# Altium schematic sheet VoltageRegulator12V.schdoc
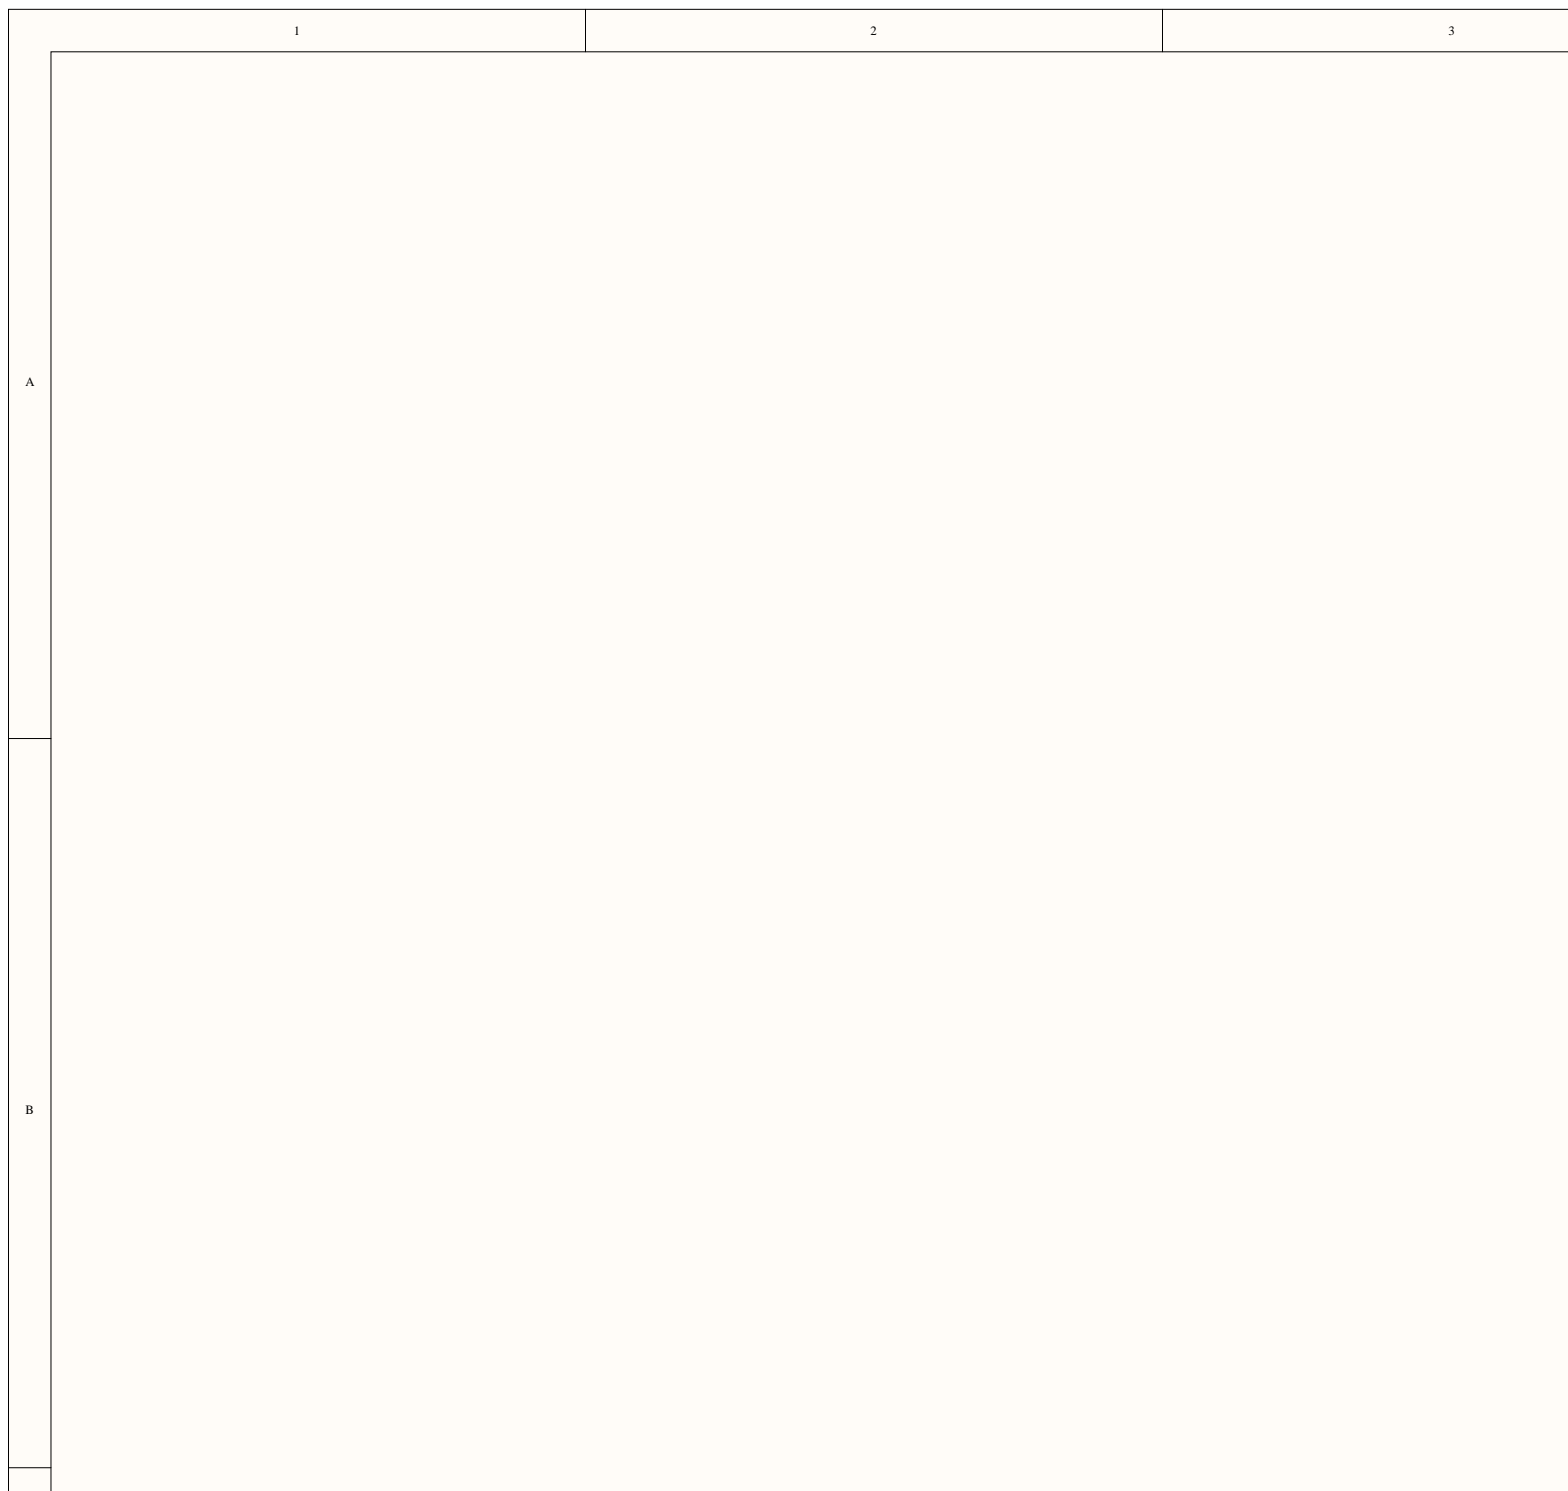
[diagram p0: VoltageRegulator12V.schdoc, top left]
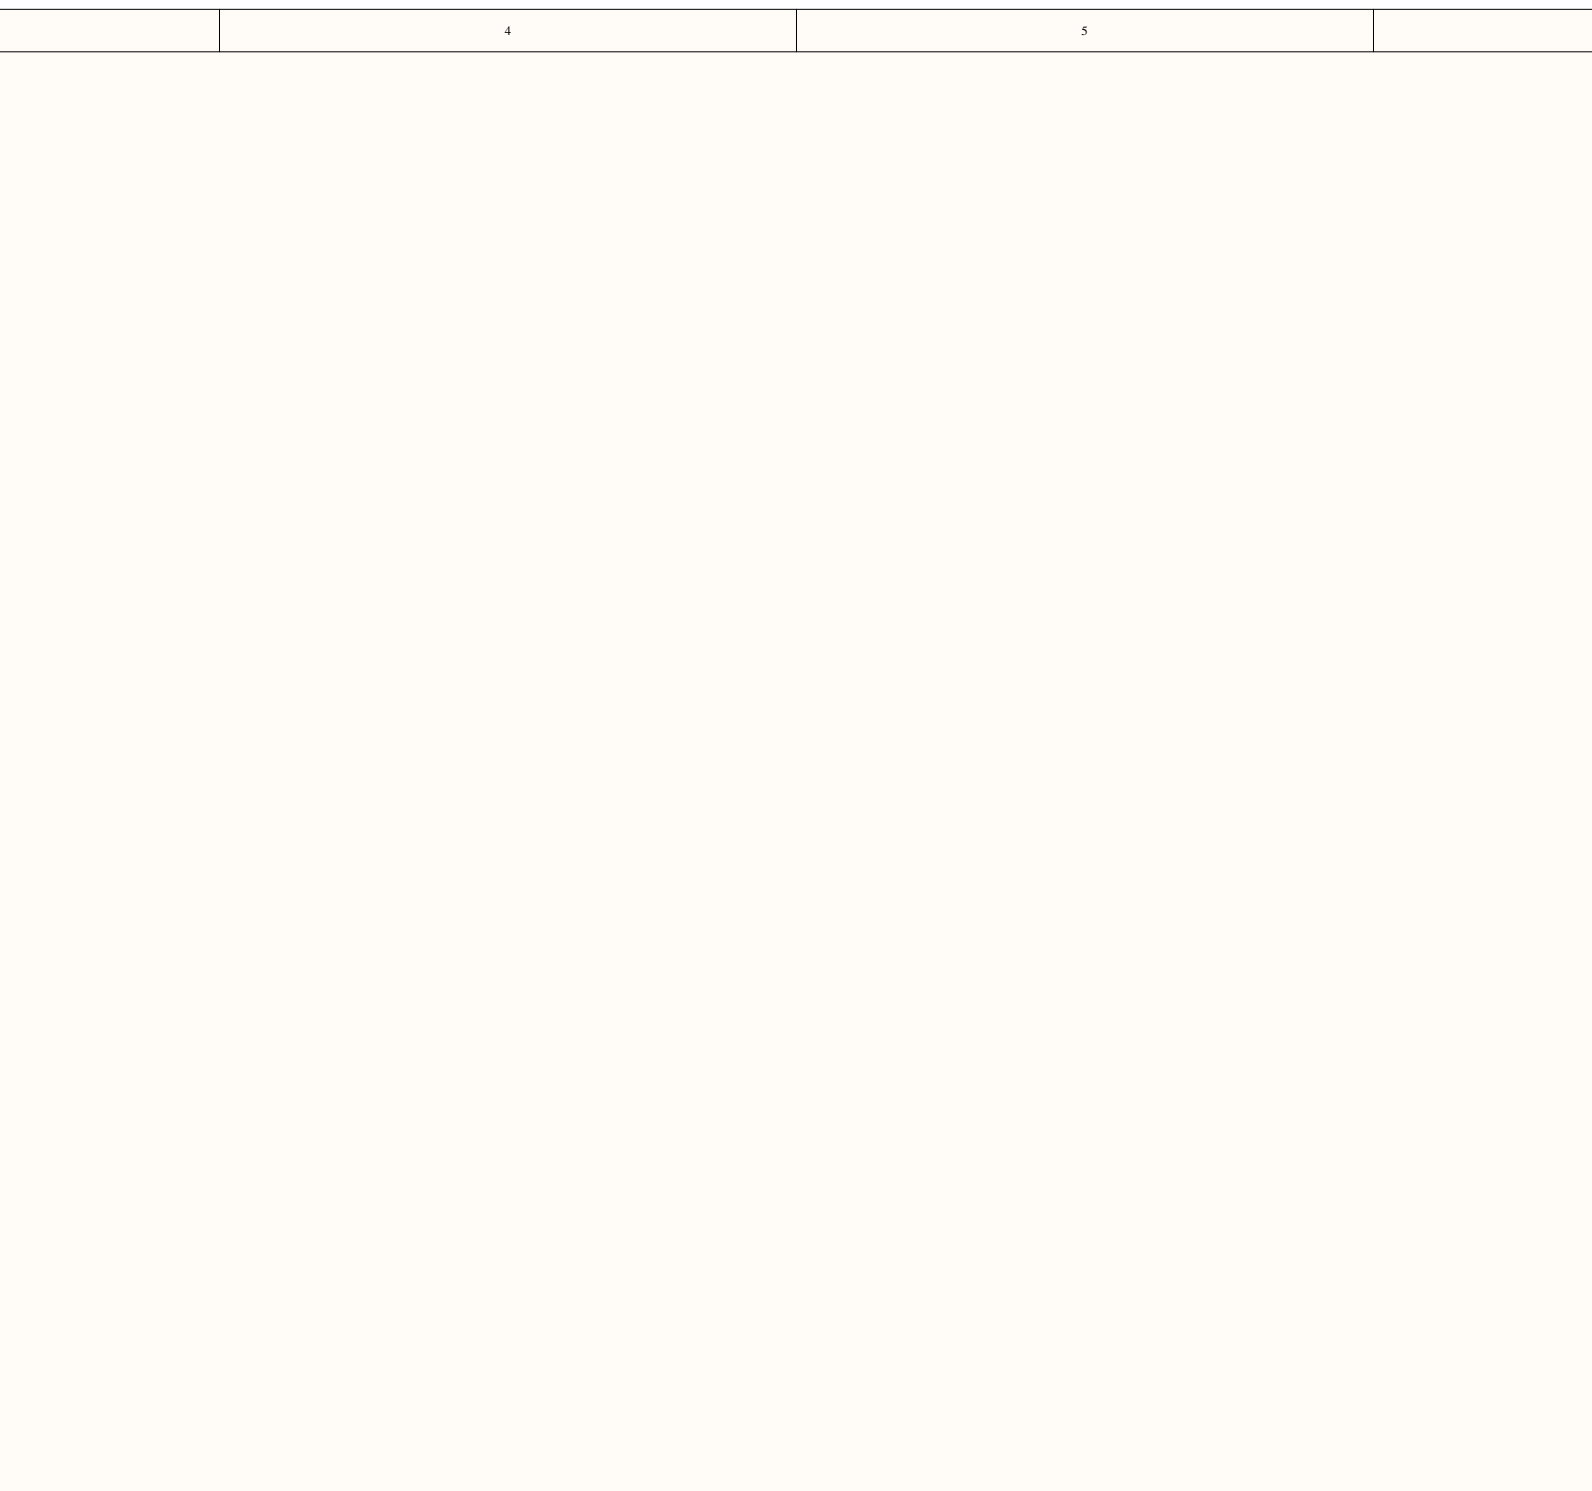
[diagram p1: VoltageRegulator12V.schdoc, top centre]
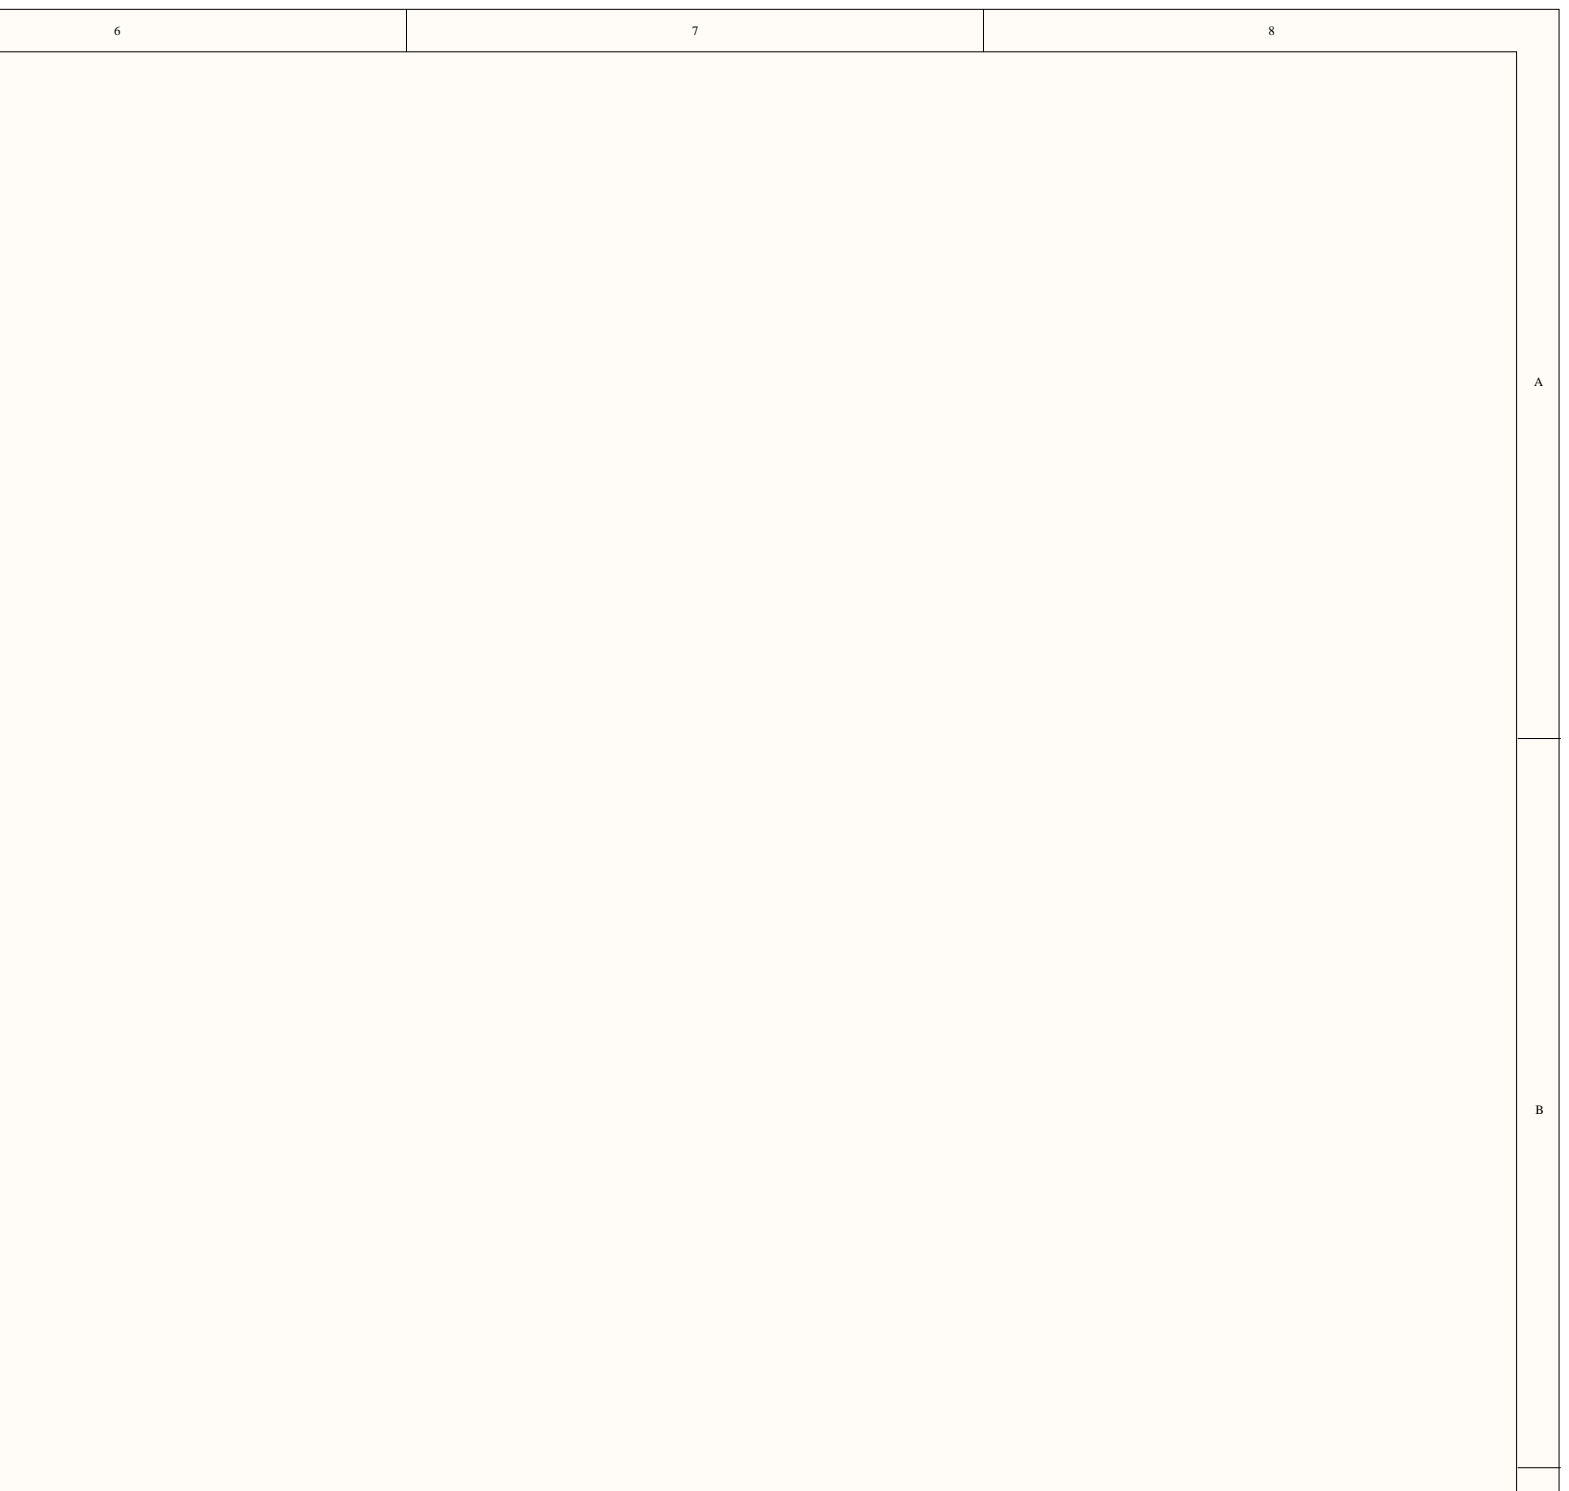
[diagram p2: VoltageRegulator12V.schdoc, top right]
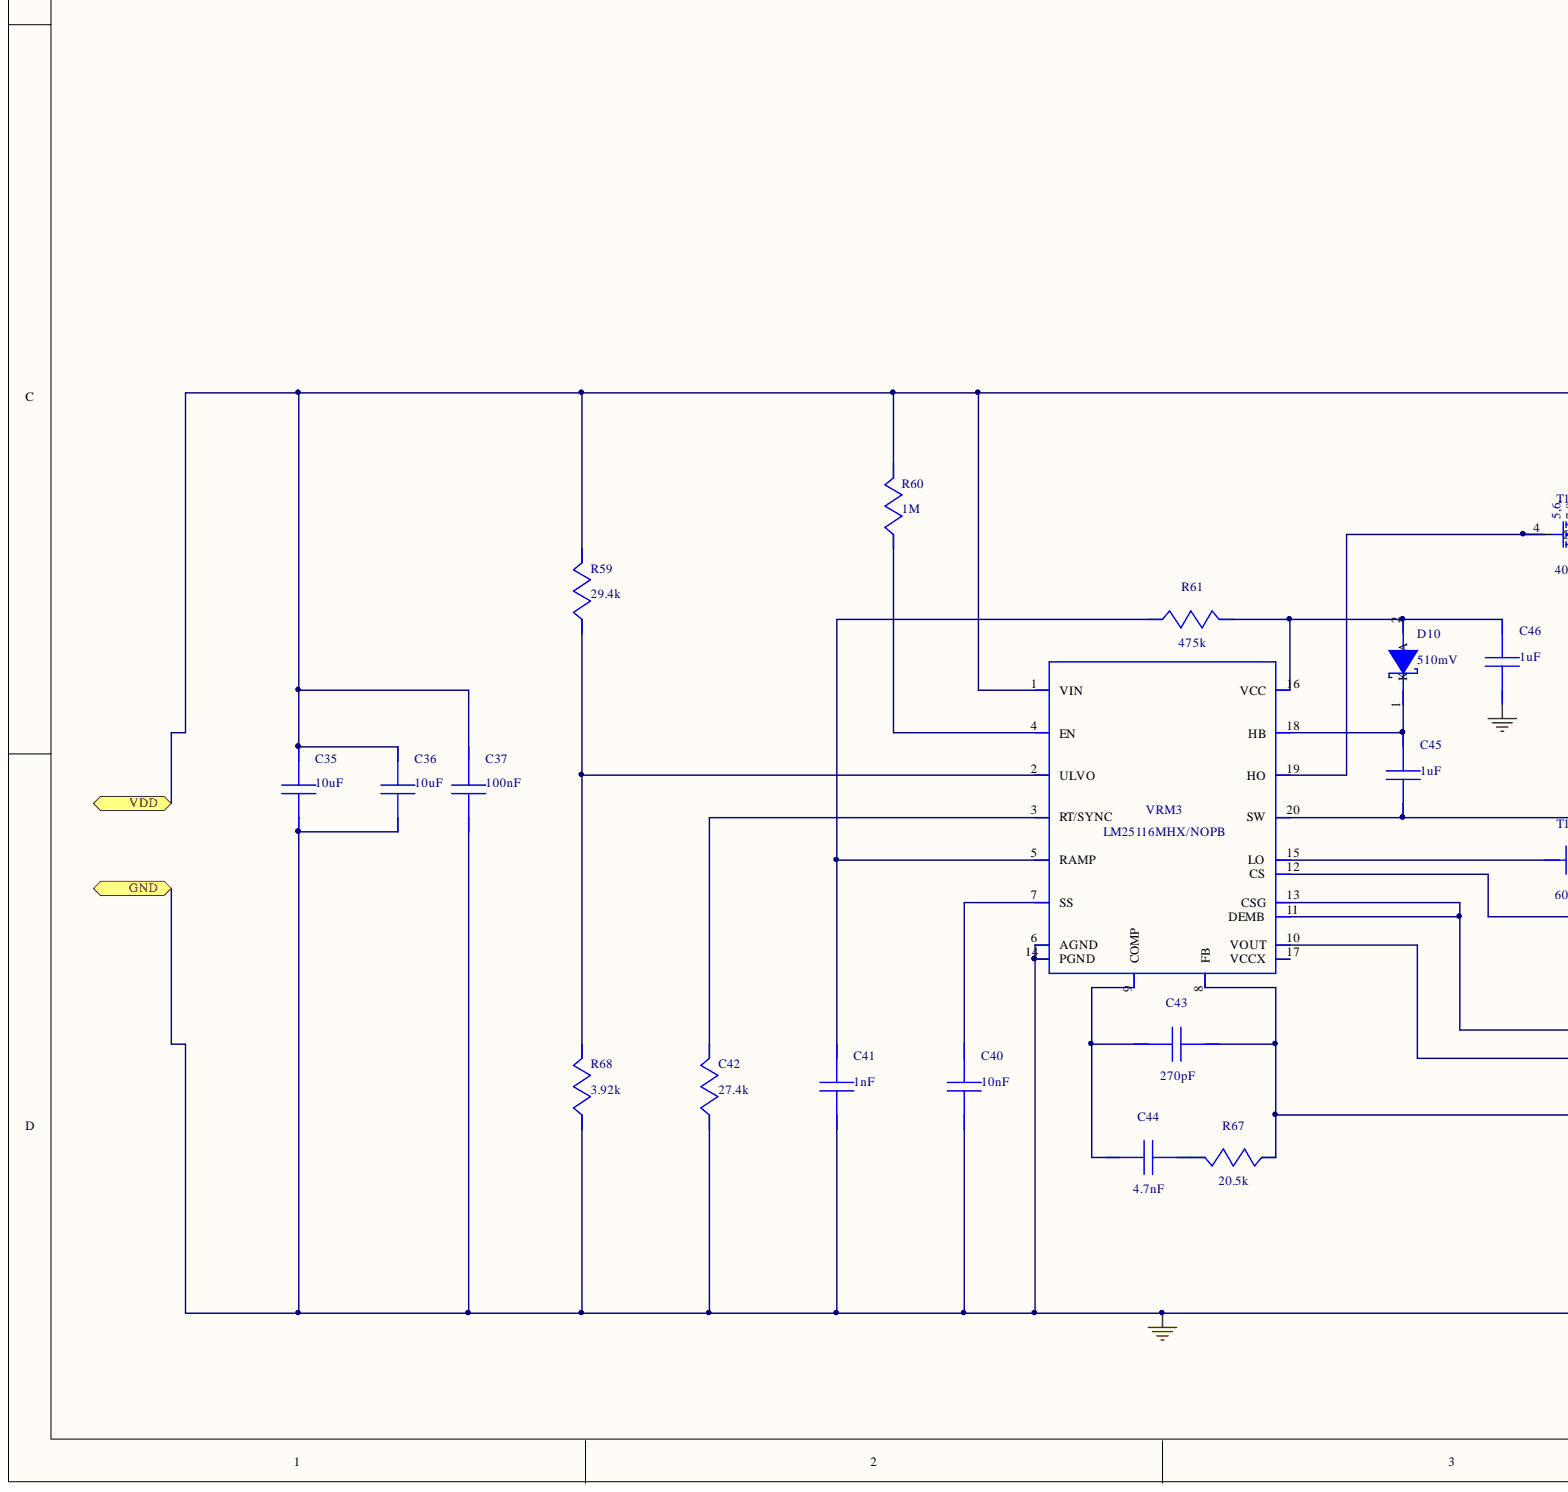
[diagram p3: VoltageRegulator12V.schdoc, bottom left]
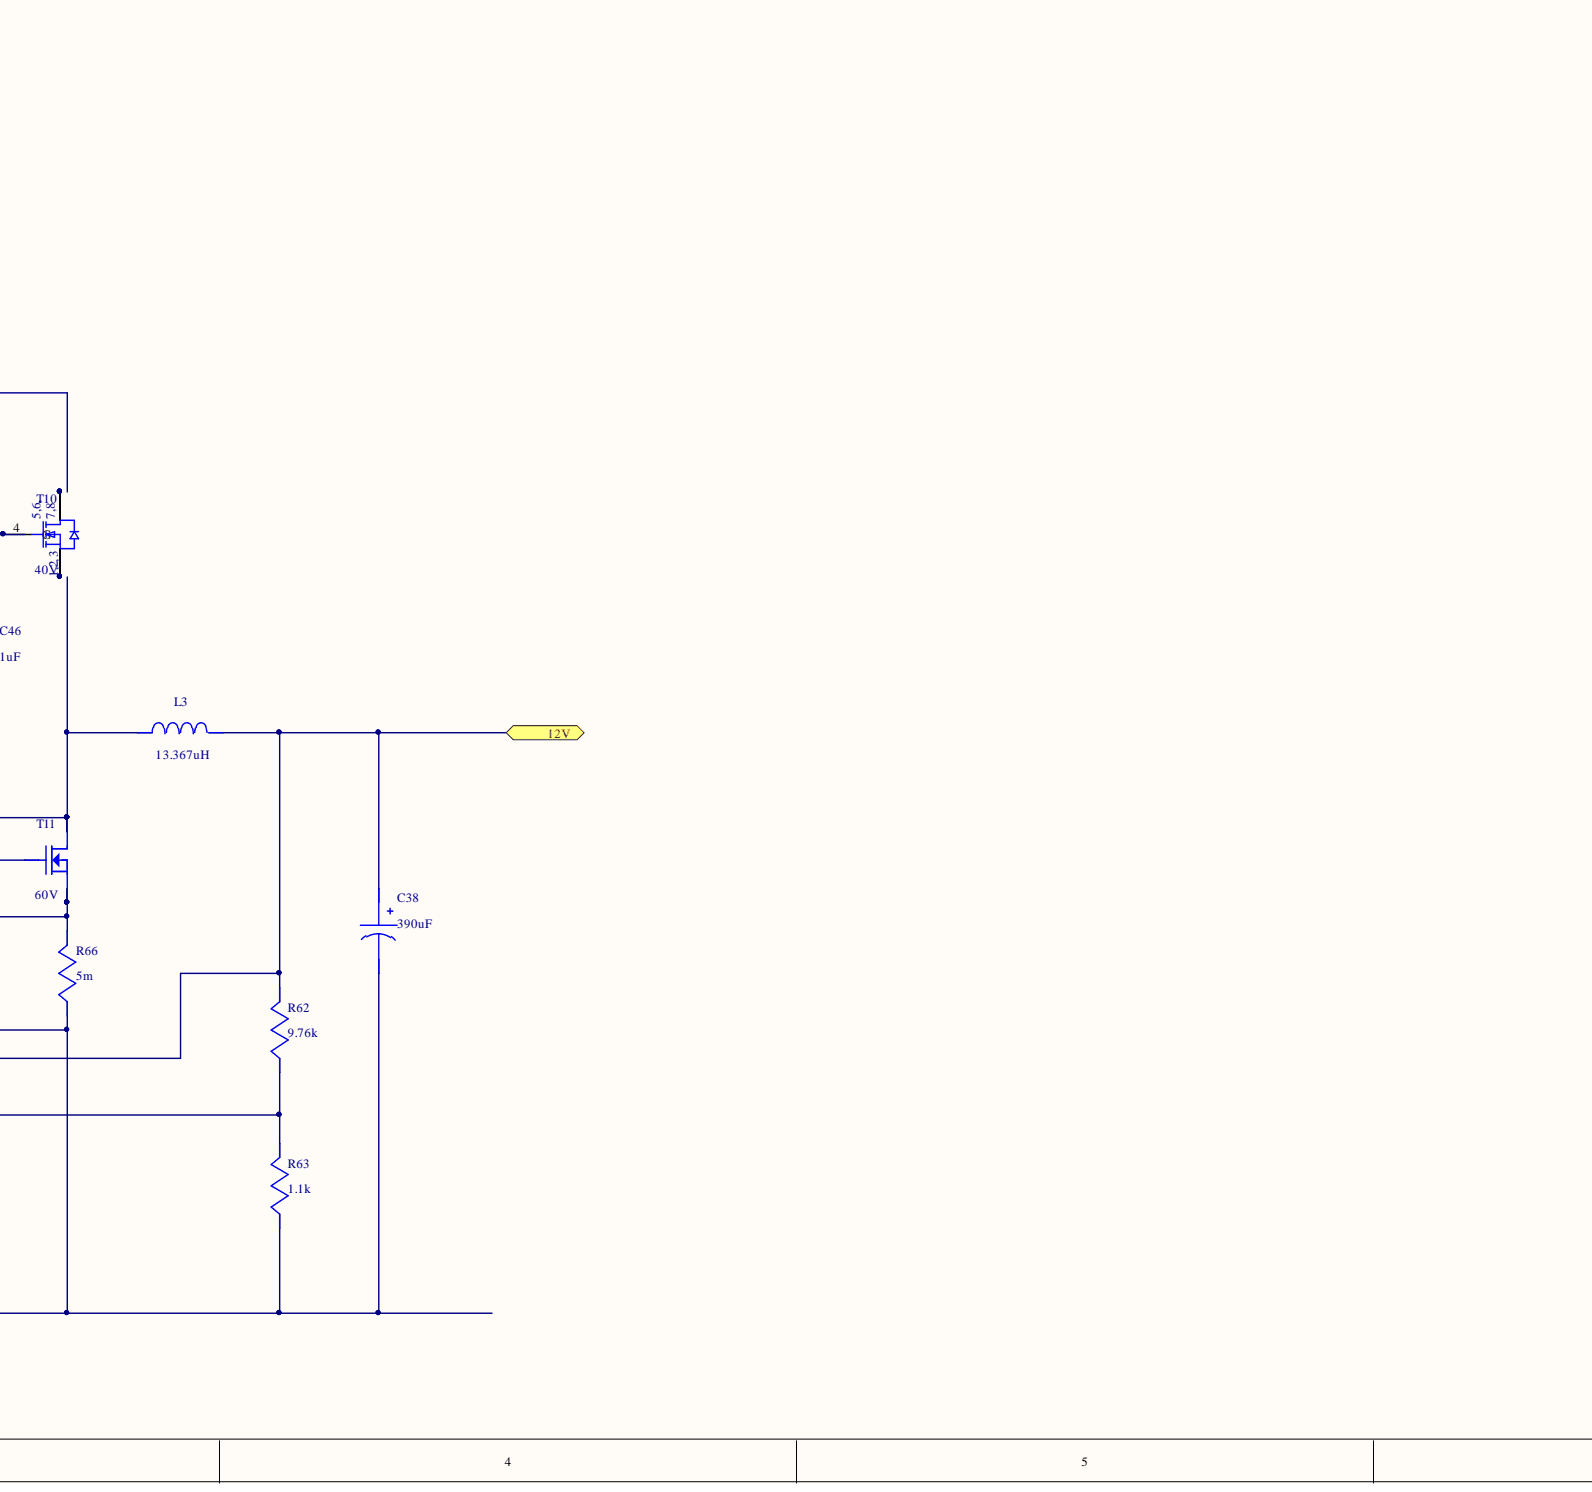
[diagram p4: VoltageRegulator12V.schdoc, bottom centre]
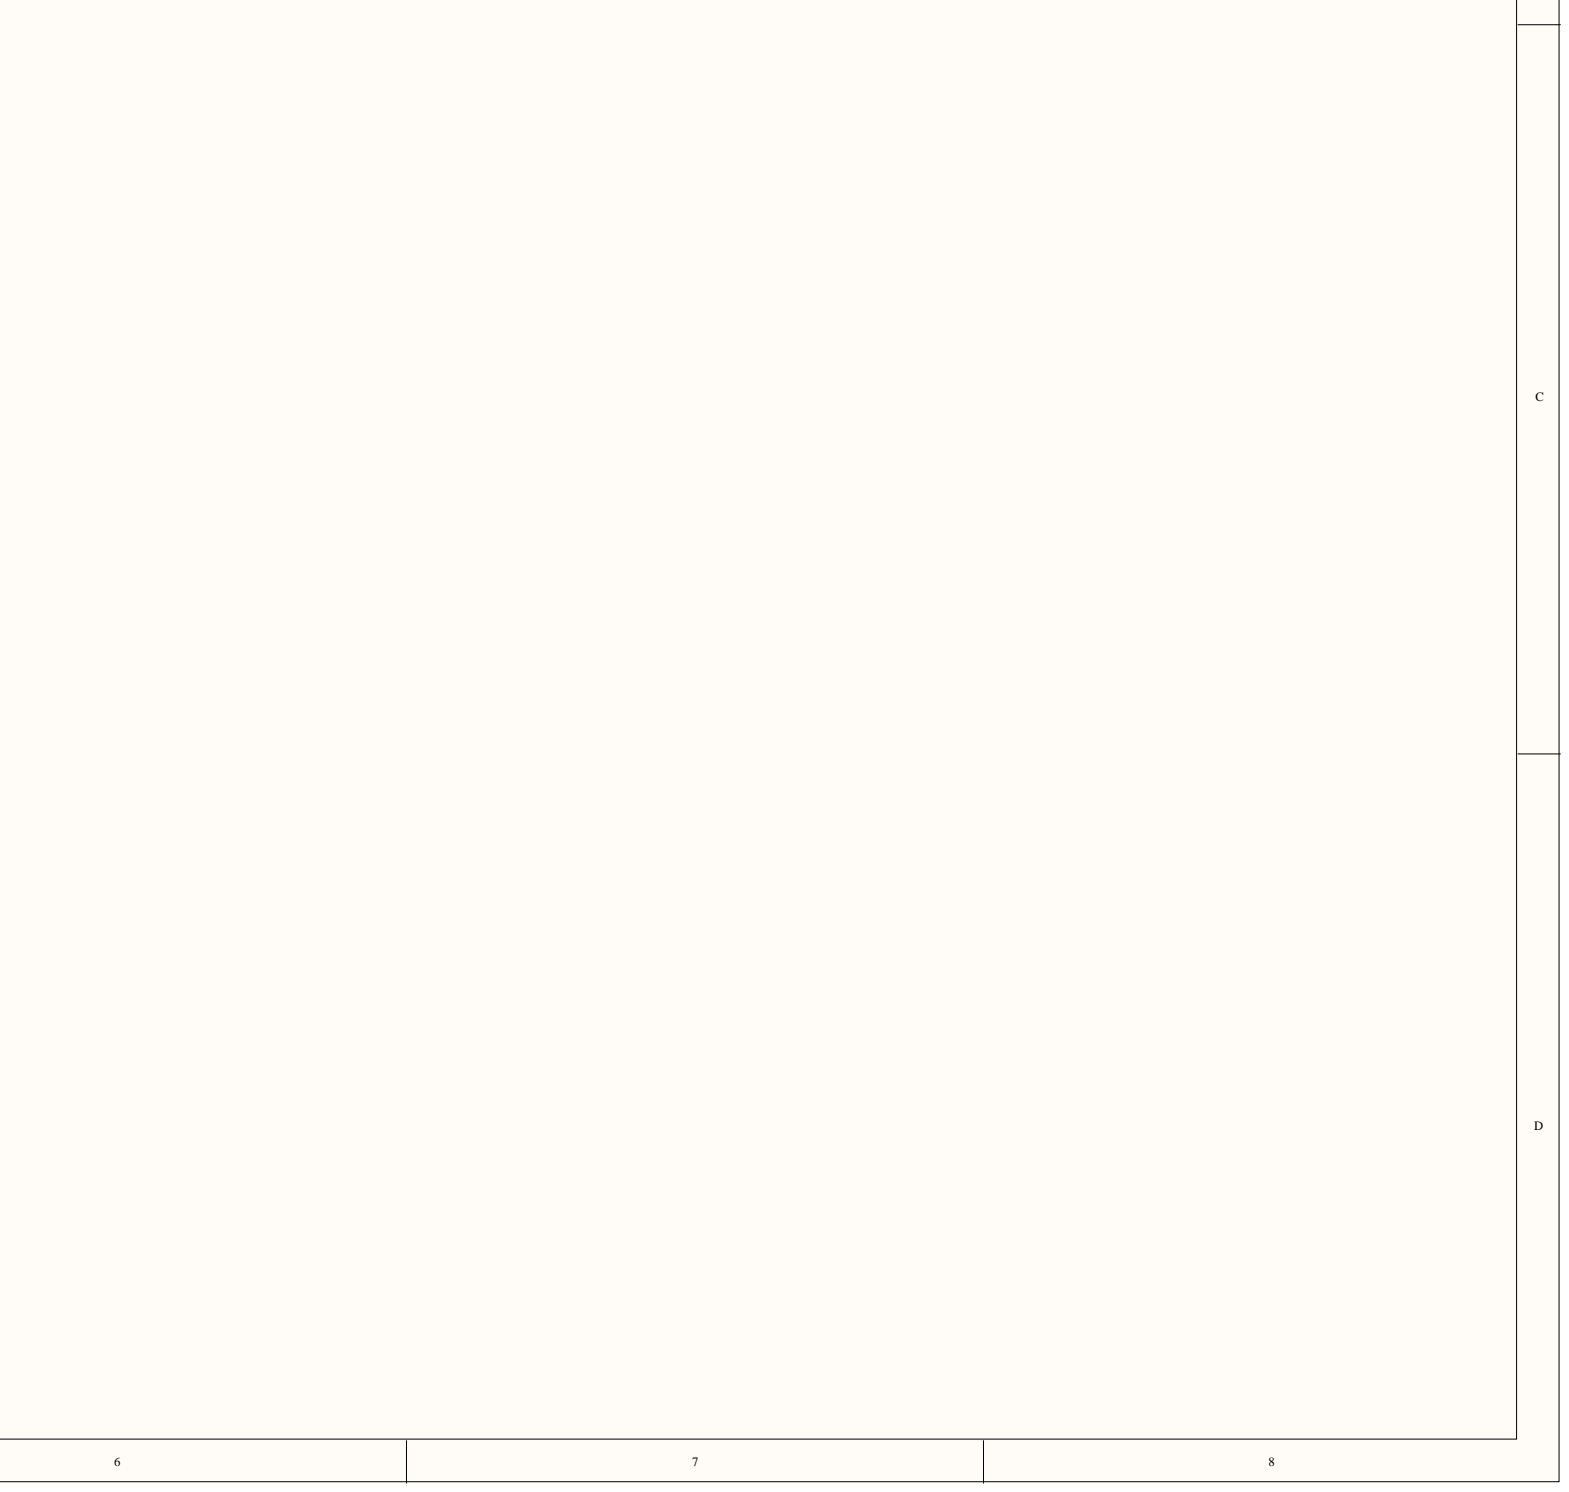
[diagram p5: VoltageRegulator12V.schdoc, bottom right]

## Parts
C35  value 10uF  (hidden comment: C3216X6S1V106K160AC)
C36  value 10uF  (hidden comment: C3216X6S1V106K160AC)
C37  value 100nF  (hidden comment: C0805C104K5RACTU)
C38  value 390uF  (hidden comment: 20SVPF390M)
C40  value 10nF  (hidden comment: CC0805KRX7R9BB103)
C41  value 1nF  (hidden comment: CL21C102JBCNFNC)
C42  value 27.4k  (hidden comment: ERJ-6ENF2742V)
C43  value 270pF  (hidden comment: CL21C271JBANFNC)
C44  value 4.7nF  (hidden comment: CC0805KRX7R9BB472)
C45  value 1uF  (hidden comment: EMK212B7105KG-T)
C46  value 1uF  (hidden comment: EMK212B7105KG-T)
D10  value 510mV  (hidden comment: CDBK0540)
L3  value 13.367uH  (hidden comment: GENERIC_INDUCTOR)
R59  value 29.4k  (hidden comment: ERJ-6ENF2942V)
R60  value 1M  (hidden comment: ERJ-6ENF1004V)
R61  value 475k  (hidden comment: ERJ-6ENF4753V)
R62  value 9.76k  (hidden comment: ERJ-6ENF9761V)
R63  value 1.1k  (hidden comment: ERJ-6ENF1101V)
R66  value 5m  (hidden comment: PRL1632-R005-F-T1)
R67  value 20.5k  (hidden comment: ERJ-6ENF2052V)
R68  value 3.92k  (hidden comment: ERJ-6ENF3921V)
T10  value 40V  (hidden comment: CSD18501Q5A)
T11  value 60V  (hidden comment: CSD18531Q5A)
VRM3  LM25116MHX/NOPB

## Ports
12V, GND, VDD

## Nets
0: C35.2 C36.2 C37.2 C38.2 C40.2 C41.2 C42.2 C46.2 R63.2 R66.2 R68.2 VRM3.6(AGND) VRM3.11(DEMB) VRM3.13(CSG) VRM3.14(PGND) VRM3.21 ; port GND
12V: C38.1 L3.2 R62.1 VRM3.10(VOUT) ; port 12V
VDD: C35.1 C36.1 C37.1 R59.1 R60.1 VRM3.1(VIN) ; port VDD
NetC40_1: C40.1 VRM3.7(SS)
NetC41_1: C41.1 R61.2 VRM3.5(RAMP)
NetC42_1: C42.1 VRM3.3(RT/SYNC)
NetC43_1: C43.1 C44.1 VRM3.9
NetC43_2: C43.2 R62.2 R63.1 R67.2 VRM3.8
NetC44_2: C44.2 R67.1
NetC45_1: C45.1 D10.1 VRM3.18(HB)
NetC45_2: C45.2 L3.1 T11.5 T11.6 T11.7 T11.8 T11.9 VRM3.20(SW)
NetC46_1: C46.1 D10.2 R61.1 VRM3.16(VCC)
NetR59_2: R59.2 R68.1 VRM3.2(ULVO)
NetR60_2: R60.2 VRM3.4(EN)
NetR66_1: R66.1 T11.1 T11.2 T11.3 VRM3.12(CS)
NetT10_1: T10.1 T10.2 T10.3
NetT10_4: T10.4(G) VRM3.19(HO)
NetT10_5: T10.5 T10.6 T10.7 T10.8 T10.9
NetT11_4: T11.4 VRM3.15(LO)

Not connected: VRM3 17(VCCX)

## Library data of the parts
C35 C36 = C3216X6S1V106K160AC: C3216X6S1V106K16; Manufacturer Name: TDK; Component Kind: Standard; Library Name: TI WEBENCH; Manufacturer Part Number: C3216X6S1V106K160AC; PackageReference: C3216X6S1V106K160AC
C37 = C0805C104K5RACTU: Manufacturer Name: Kemet; Component Kind: Standard; Description: C0805C104K5RACTU; Library Name: TI WEBENCH; Manufacturer Part Number: C0805C104K5RACTU; PackageReference: C0805C104K5RACTU
C38 = 20SVPF390M: Manufacturer Name: Panasonic; Component Kind: Standard; Description: 20SVPF390M; Library Name: TI WEBENCH; Manufacturer Part Number: 20SVPF390M; PackageReference: 20SVPF390M
C40 = CC0805KRX7R9BB103: CC0805KRX7R9BB10; Manufacturer Name: Yageo America; Component Kind: Standard; Library Name: TI WEBENCH; Manufacturer Part Number: CC0805KRX7R9BB103; PackageReference: CC0805KRX7R9BB103
C41 = CL21C102JBCNFNC: Manufacturer Name: Samsung Electro-Mechanics; Component Kind: Standard; Description: CL21C102JBCNFNC; Library Name: TI WEBENCH; Manufacturer Part Number: CL21C102JBCNFNC; PackageReference: CL21C102JBCNFNC
C42 = ERJ-6ENF2742V: Manufacturer Name: Panasonic; Component Kind: Standard; Description: ERJ-6ENF2742V; Library Name: TI WEBENCH; Manufacturer Part Number: ERJ-6ENF2742V; PackageReference: ERJ-6ENF2742V
C43 = CL21C271JBANFNC: Manufacturer Name: Samsung Electro-Mechanics; Component Kind: Standard; Description: CL21C271JBANFNC; Library Name: TI WEBENCH; Manufacturer Part Number: CL21C271JBANFNC; PackageReference: CL21C271JBANFNC
C44 = CC0805KRX7R9BB472: CC0805KRX7R9BB47; Manufacturer Name: Yageo America; Component Kind: Standard; Library Name: TI WEBENCH; Manufacturer Part Number: CC0805KRX7R9BB472; PackageReference: CC0805KRX7R9BB472
C45 C46 = EMK212B7105KG-T: Manufacturer Name: Taiyo Yuden; Component Kind: Standard; Description: EMK212B7105KG-T; Library Name: TI WEBENCH; Manufacturer Part Number: EMK212B7105KG-T; PackageReference: EMK212B7105KG-T
D10 = CDBK0540: Manufacturer Name: Comchip Technology; Component Kind: Standard; Description: CDBK0540; Library Name: TI WEBENCH; Manufacturer Part Number: CDBK0540; PackageReference: CDBK0540
L3 = GENERIC_INDUCTOR: CUSTOM1; Manufacturer Name: CUSTOM; Component Kind: Standard; Library Name: TI WEBENCH; Manufacturer Part Number: GENERIC_INDUCTOR; PackageReference: CUSTOM
R59 = ERJ-6ENF2942V: Manufacturer Name: Panasonic; Component Kind: Standard; Description: ERJ-6ENF2942V; Library Name: TI WEBENCH; Manufacturer Part Number: ERJ-6ENF2942V; PackageReference: ERJ-6ENF2942V
R60 = ERJ-6ENF1004V: Manufacturer Name: Panasonic; Component Kind: Standard; Description: ERJ-6ENF1004V; Library Name: TI WEBENCH; Manufacturer Part Number: ERJ-6ENF1004V; PackageReference: ERJ-6ENF1004V
R61 = ERJ-6ENF4753V: Manufacturer Name: Panasonic; Component Kind: Standard; Description: ERJ-6ENF4753V; Library Name: TI WEBENCH; Manufacturer Part Number: ERJ-6ENF4753V; PackageReference: ERJ-6ENF4753V
R62 = ERJ-6ENF9761V: Manufacturer Name: Panasonic; Component Kind: Standard; Description: ERJ-6ENF9761V; Library Name: TI WEBENCH; Manufacturer Part Number: ERJ-6ENF9761V; PackageReference: ERJ-6ENF9761V
R63 = ERJ-6ENF1101V: Manufacturer Name: Panasonic; Component Kind: Standard; Description: ERJ-6ENF1101V; Library Name: TI WEBENCH; Manufacturer Part Number: ERJ-6ENF1101V; PackageReference: ERJ-6ENF1101V
R66 = PRL1632-R005-F-T1: PRL1632-R005-F-T; Manufacturer Name: Susumu Co Ltd; Component Kind: Standard; Library Name: TI WEBENCH; Manufacturer Part Number: PRL1632-R005-F-T1; PackageReference: PRL1632-R005-F-T1
R67 = ERJ-6ENF2052V: Manufacturer Name: Panasonic; Component Kind: Standard; Description: ERJ-6ENF2052V; Library Name: TI WEBENCH; Manufacturer Part Number: ERJ-6ENF2052V; PackageReference: ERJ-6ENF2052V
R68 = ERJ-6ENF3921V: Manufacturer Name: Panasonic; Component Kind: Standard; Description: ERJ-6ENF3921V; Library Name: TI WEBENCH; Manufacturer Part Number: ERJ-6ENF3921V; PackageReference: ERJ-6ENF3921V
T10 = CSD18501Q5A: Manufacturer Name: Texas Instruments; Component Kind: Standard; Description: CSD18501Q5A; Library Name: TI WEBENCH; Manufacturer Part Number: CSD18501Q5A; PackageReference: CSD18501Q5A
T11 = CSD18531Q5A: Manufacturer Name: Texas Instruments; Component Kind: Standard; Description: CSD18531Q5A; Library Name: TI WEBENCH; Manufacturer Part Number: CSD18531Q5A; PackageReference: CSD18531Q5A
VRM3 = LM25116MHX/NOPB: Manufacturer Name: Texas Instruments; Component Kind: Standard; Description: LM25116MHX/NOPB; Library Name: TI WEBENCH; Manufacturer Part Number: LM25116MHX/NOPB; PackageReference: LM25116MHX/NOPB; Value: N/A
